# Altium schematic sheet TPS5430_buck_converter.SchDoc(TPS5430_buck_converter) (continued)

## Library data of the parts
C1 = C1608X7R1H103K080AA: C Series 0603 10 nF 50 V ±10 % Tolerance X7R SMT Multilayer Ceramic Capacitor; Manufacturer: TDK; Manufacturer Lifecycle Status: PRODUCTION; Tolerance: 10%; Case Code (Imperial): 0603; Case Code (Metric): 1608; Case/Package: 0603; Category: Ceramic Capacitors; China RoHS: Compliant; Component Kind: Standard; ComponentLink1Description: https://datasheet.octopart.com:443/C1608X7R1H103K080AA-TDK-datasheet-175423548.pdf?src-supplier=TME; ComponentLink1URL: https://datasheet.octopart.com:443/C1608X7R1H103K080AA-TDK-datasheet-175423548.pdf?src-supplier=TME; ComponentLink2Description: https://datasheet.octopart.com:443/C1608X7R1H103K080AA-TDK-datasheet-182135025.pdf?src-supplier=TME; ComponentLink2URL: https://datasheet.octopart.com:443/C1608X7R1H103K080AA-TDK-datasheet-182135025.pdf?src-supplier=TME; ComponentLink3Description: https://datasheet.octopart.com:443/C1608X7R1H103K080AA-TDK-datasheet-159617022.pdf?src-supplier=TME; ComponentLink3URL: https://datasheet.octopart.com:443/C1608X7R1H103K080AA-TDK-datasheet-159617022.pdf?src-supplier=TME; ComponentLink4Description: https://datasheet.octopart.com:443/C1608X7R1H103K080AA-TDK-datasheet-151546523.pdf?src-supplier=ODG+(Origin+Data+Global); ComponentLink4URL: https://datasheet.octopart.com:443/C1608X7R1H103K080AA-TDK-datasheet-151546523.pdf?src-supplier=ODG+(Origin+Data+Global); ComponentLink5Description: https://datasheet.octopart.com:443/C1608X7R1H103K080AA-TDK-datasheet-82475248.pdf?src-supplier=Newark; ComponentLink5URL: https://datasheet.octopart.com:443/C1608X7R1H103K080AA-TDK-datasheet-82475248.pdf?src-supplier=Newark; ComponentLink6Description: https://datasheet.octopart.com:443/C1608X7R1H103K080AA-TDK-datasheet-13376850.pdf?src-supplier=Newark; ComponentLink6URL: https://datasheet.octopart.com:443/C1608X7R1H103K080AA-TDK-datasheet-13376850.pdf?src-supplier=Newark; ComponentLink7Description: https://datasheet.octopart.com:443/C1608X7R1H103K080AA-TDK-datasheet-10978788.pdf?src-supplier=Newark; ComponentLink7URL: https://datasheet.octopart.com:443/C1608X7R1H103K080AA-TDK-datasheet-10978788.pdf?src-supplier=Newark; ComponentLink8Description: https://datasheet.octopart.com/C1608X7R1H103K080AA-TDK-datasheet-41194876.pdf; ComponentLink8URL: https://datasheet.octopart.com/C1608X7R1H103K080AA-TDK-datasheet-41194876.pdf; ComponentLink9Description: https://datasheet.octopart.com:443/C1608X7R1H103K080AA-TDK-datasheet-21217870.pdf?src-supplier=element14+APAC; ComponentLink9URL: https://datasheet.octopart.com:443/C1608X7R1H103K080AA-TDK-datasheet-21217870.pdf?src-supplier=element14+APAC; ComponentLink10Description: https://datasheet.octopart.com:443/C1608X7R1H103K080AA-TDK-datasheet-8852320.pdf?src-supplier=Farnell; ComponentLink10URL: https://datasheet.octopart.com:443/C1608X7R1H103K080AA-TDK-datasheet-8852320.pdf?src-supplier=Farnell; ComponentLink11Description: https://datasheet.octopart.com:443/C1608X7R1H103K080AA-TDK-datasheet-15994800.pdf?src-supplier=Farnell; ComponentLink11URL: https://datasheet.octopart.com:443/C1608X7R1H103K080AA-TDK-datasheet-15994800.pdf?src-supplier=Farnell; ComponentLink12Description: https://datasheet.octopart.com:443/C1608X7R1H103K080AA-TDK-datasheet-12509848.pdf?src-supplier=Future+Electronics; ComponentLink12URL: https://datasheet.octopart.com:443/C1608X7R1H103K080AA-TDK-datasheet-12509848.pdf?src-supplier=Future+Electronics; ComponentLink13Description: https://datasheet.octopart.com:443/C1608X7R1H103K080AA-TDK-datasheet-8359770.pdf?src-supplier=Future+Electronics; ComponentLink13URL: https://datasheet.octopart.com:443/C1608X7R1H103K080AA-TDK-datasheet-8359770.pdf?src-supplier=Future+Electronics; ComponentLink14Description: https://datasheet.octopart.com:443/C1608X7R1H103K080AA-TDK-datasheet-8324322.pdf?src-supplier=Future+Electronics; ComponentLink14URL: https://datasheet.octopart.com:443/C1608X7R1H103K080AA-TDK-datasheet-8324322.pdf?src-supplier=Future+Electronics; ComponentLink15Description: https://datasheet.octopart.com:443/C1608X7R1H103K080AA-TDK-datasheet-128946.pdf?src-supplier=Future+Electronics; ComponentLink15URL: https://datasheet.octopart.com:443/C1608X7R1H103K080AA-TDK-datasheet-128946.pdf?src-supplier=Future+Electronics; Composition: Ceramic; Depth: 800µm; Dielectric: X7R; Dielectric Material: Ceramic; Height: 800µm; Lead Free: Lead Free; Length: 1.6mm; Library Name: TPS5430_buck _converter.SchLib; Lifecycle Status: Production; Manufacturer Part Number: C1608X7R1H103K080AA; Material: Ceramic; Max Length: 1.7mm; Max Operating Temperature: 125°C; Max Width: 900µm; Min Length: 1.5mm; Min Operating Temperature: -55°C; Min Width: 700µm; Mounting Technology: Surface Mount; Number of Terminals: 2; Pricing: 1=0.1, 10=0.034, 50=0.034, 100=0.03, 1000=0.019, 10000=0.010 (USD); Radiation Hardening: No; REACH SVHC: Yes; RoHS: Compliant; Schedule B: 8532240020, 8532240020|8532240020, 8532240020|8532240020|8532240020, 8532240020|8532240020|8532240020|8532240020; Stock: 251669; Supplier: Mouser; Supplier Part Number: 810-C1608X7R1H103K; Termination: SMD/SMT; Value: 10nF; Voltage: 50V; Voltage Rating: 50V; Voltage Rating (DC): 50V; Weight: 2.012816mg; Width: 800µm
C2 C3 = C4532X7R1H475M200KB: Multilayer Ceramic Capacitor, C Series, 4.7 - F, - 20%, X7R, 50 V, 1812 [4532 Metric]; Manufacturer: TDK; Manufacturer Lifecycle Status: PRODUCTION (NOT RECOMMENDED FOR NEW DESIGN); Value: 4.7µF; Voltage Rating: 50V; Case Code (Imperial): 1812; Case Code (Metric): 4532; Case/Package: 1812; Category: Ceramic Capacitors; China RoHS: Compliant; Component Kind: Standard; ComponentLink1Description: https://datasheet.octopart.com:443/C4532X7R1H475M200KB-TDK-datasheet-175423548.pdf?src-supplier=IHS; ComponentLink1URL: https://datasheet.octopart.com:443/C4532X7R1H475M200KB-TDK-datasheet-175423548.pdf?src-supplier=IHS; ComponentLink2Description: https://sigma.octopart.com:443/138898468/technical_drawing/TDK-C4532X7R1H475M200KB.pdf?src-supplier=Upverter; ComponentLink2URL: https://sigma.octopart.com:443/138898468/technical_drawing/TDK-C4532X7R1H475M200KB.pdf?src-supplier=Upverter; ComponentLink3Description: https://datasheet.octopart.com:443/C4532X7R1H475M200KB-TDK-datasheet-14433597.pdf?src-supplier=Upverter; ComponentLink3URL: https://datasheet.octopart.com:443/C4532X7R1H475M200KB-TDK-datasheet-14433597.pdf?src-supplier=Upverter; ComponentLink4Description: https://datasheet.octopart.com:443/C4532X7R1H475M200KB-TDK-datasheet-151546523.pdf?src-supplier=ODG+(Origin+Data+Global); ComponentLink4URL: https://datasheet.octopart.com:443/C4532X7R1H475M200KB-TDK-datasheet-151546523.pdf?src-supplier=ODG+(Origin+Data+Global); ComponentLink5Description: https://datasheet.octopart.com/C4532X7R1H475M200KB-TDK-datasheet-41194876.pdf; ComponentLink5URL: https://datasheet.octopart.com/C4532X7R1H475M200KB-TDK-datasheet-41194876.pdf; ComponentLink6Description: https://datasheet.octopart.com/C4532X7R1H475M200KB-TDK-datasheet-15532982.pdf; ComponentLink6URL: https://datasheet.octopart.com/C4532X7R1H475M200KB-TDK-datasheet-15532982.pdf; ComponentLink7Description: https://datasheet.octopart.com:443/C4532X7R1H475M200KB-TDK-datasheet-10978788.pdf?src-supplier=Newark; ComponentLink7URL: https://datasheet.octopart.com:443/C4532X7R1H475M200KB-TDK-datasheet-10978788.pdf?src-supplier=Newark; ComponentLink8Description: https://datasheet.octopart.com:443/C4532X7R1H475M200KB-TDK-datasheet-13376850.pdf?src-supplier=Newark; ComponentLink8URL: https://datasheet.octopart.com:443/C4532X7R1H475M200KB-TDK-datasheet-13376850.pdf?src-supplier=Newark; ComponentLink9Description: https://datasheet.octopart.com:443/C4532X7R1H475M200KB-TDK-datasheet-8852320.pdf?src-supplier=Newark; ComponentLink9URL: https://datasheet.octopart.com:443/C4532X7R1H475M200KB-TDK-datasheet-8852320.pdf?src-supplier=Newark; ComponentLink10Description: https://datasheet.octopart.com:443/C4532X7R1H475M200KB-TDK-datasheet-82475248.pdf?src-supplier=Newark; ComponentLink10URL: https://datasheet.octopart.com:443/C4532X7R1H475M200KB-TDK-datasheet-82475248.pdf?src-supplier=Newark; ComponentLink11Description: https://datasheet.octopart.com:443/C4532X7R1H475M200KB-TDK-datasheet-23766614.pdf?src-supplier=Farnell; ComponentLink11URL: https://datasheet.octopart.com:443/C4532X7R1H475M200KB-TDK-datasheet-23766614.pdf?src-supplier=Farnell; ComponentLink12Description: https://datasheet.octopart.com:443/C4532X7R1H475M200KB-TDK-datasheet-12509848.pdf?src-supplier=Future+Electronics; ComponentLink12URL: https://datasheet.octopart.com:443/C4532X7R1H475M200KB-TDK-datasheet-12509848.pdf?src-supplier=Future+Electronics; ComponentLink13Description: https://datasheet.octopart.com:443/C4532X7R1H475M200KB-TDK-datasheet-8359770.pdf?src-supplier=Future+Electronics; ComponentLink13URL: https://datasheet.octopart.com:443/C4532X7R1H475M200KB-TDK-datasheet-8359770.pdf?src-supplier=Future+Electronics; ComponentLink14Description: https://datasheet.octopart.com:443/C4532X7R1H475M200KB-TDK-datasheet-8324322.pdf?src-supplier=Future+Electronics; ComponentLink14URL: https://datasheet.octopart.com:443/C4532X7R1H475M200KB-TDK-datasheet-8324322.pdf?src-supplier=Future+Electronics; ComponentLink15Description: https://datasheet.octopart.com:443/C4532X7R1H475M200KB-TDK-datasheet-128946.pdf?src-supplier=Future+Electronics; ComponentLink15URL: https://datasheet.octopart.com:443/C4532X7R1H475M200KB-TDK-datasheet-128946.pdf?src-supplier=Future+Electronics; ComponentLink16Description: http://sigma.octopart.com:80/rohs_certificate/C-Series-Ceramic-Chip-Capacitors-TDK-family-108.pdf?src-supplier=Compliance+Statements; ComponentLink16URL: http://sigma.octopart.com:80/rohs_certificate/C-Series-Ceramic-Chip-Capacitors-TDK-family-108.pdf?src-supplier=Compliance+Statements; Composition: Ceramic; Depth: 3.2mm; Dielectric: X7R; Dielectric Material: Ceramic; Height: 2mm; Lead Free: Lead Free; Length: 4.5mm; Library Name: TPS5430_buck _converter.SchLib; Lifecycle Status: NRND; Manufacturer Part Number: C4532X7R1H475M200KB; Material: Ceramic; Max Length: 4.9mm; Max Operating Temperature: 125°C; Max Width: 3.6mm; Min Length: 4.1mm; Min Operating Temperature: -55°C; Min Width: 2.8mm; Mounting Technology: Surface Mount; Number of Terminals: 2; Pins: 2; Pricing: 1=0.83, 10=0.525, 50=0.525, 100=0.479, 1000=0.323, 10000=0.322 (USD); REACH SVHC: Yes; RoHS: Compliant; Schedule B: 8532240020, 8532240020|8532240020|8532240020|8532240020|8532240020|8532240020|8532240020, 8532240020|8532240020|8532240020|8532240020|8532240020|8532240020|8532240020|8532240020, 8532240020|8532240020|8532240020|8532240020|8532240020|8532240020|8532240020|8532240020|8532240020; Stock: 11670; Supplier: Mouser; Supplier Part Number: 810-C4532X7R1H475M-9; Termination: SMD/SMT; Tolerance: 20%; Voltage Rating (DC): 50V; Weight: 26.988746mg; Width: 3.2mm
C4 = 10TPB220M: Cap Tant Solid 220uF 10V D4 CASE 20% (7.3 X 4.3 X 3.8mm) SMD 7343 0.04 Ohm 105 C Embossed T/R; Manufacturer: Panasonic; Manufacturer Lifecycle Status: ACTIVE; Part Series: TPB; Dissipation Factor: 10%; ESR (Equivalent Series Resistance): 40mΩ; Leakage Current: 220µA; Life (Hours): 2000hours; Material: Polymer; Polarity: Polar; Ripple Current: 3A; Value: 220µF; Case Code (Imperial): 2917; Case Code (Metric): 7343; Case/Package: 2917; Category: Tantalum Capacitors; Component Kind: Standard; ComponentLink1Description: https://datasheet.octopart.com:443/10TPB220M-Panasonic-datasheet-182100652.pdf?src-supplier=IHS; ComponentLink1URL: https://datasheet.octopart.com:443/10TPB220M-Panasonic-datasheet-182100652.pdf?src-supplier=IHS; ComponentLink2Description: https://datasheet.octopart.com:443/10TPB220M-Panasonic-datasheet-61627562.pdf?src-supplier=Panasonic; ComponentLink2URL: https://datasheet.octopart.com:443/10TPB220M-Panasonic-datasheet-61627562.pdf?src-supplier=Panasonic; ComponentLink3Description: https://sigma.octopart.com:443/151312658/technical_drawing/Panasonic-10TPB220M.pdf?src-supplier=Upverter; ComponentLink3URL: https://sigma.octopart.com:443/151312658/technical_drawing/Panasonic-10TPB220M.pdf?src-supplier=Upverter; ComponentLink4Description: https://datasheet.octopart.com:443/10TPB220M-Panasonic-datasheet-136612378.pdf?src-supplier=Farnell; ComponentLink4URL: https://datasheet.octopart.com:443/10TPB220M-Panasonic-datasheet-136612378.pdf?src-supplier=Farnell; ComponentLink5Description: https://datasheet.octopart.com:443/10TPB220M-Panasonic-datasheet-18423120.pdf?src-supplier=Farnell; ComponentLink5URL: https://datasheet.octopart.com:443/10TPB220M-Panasonic-datasheet-18423120.pdf?src-supplier=Farnell; ComponentLink6Description: https://datasheet.octopart.com:443/10TPB220M-Panasonic-datasheet-17275940.pdf?src-supplier=Future+Electronics; ComponentLink6URL: https://datasheet.octopart.com:443/10TPB220M-Panasonic-datasheet-17275940.pdf?src-supplier=Future+Electronics; ComponentLink7Description: https://datasheet.octopart.com:443/10TPB220M-Panasonic-datasheet-154766054.pdf?src-supplier=Master+Electronics; ComponentLink7URL: https://datasheet.octopart.com:443/10TPB220M-Panasonic-datasheet-154766054.pdf?src-supplier=Master+Electronics; ComponentLink8Description: https://datasheet.octopart.com:443/10TPB220M..-Panasonic-datasheet-527723.pdf?src-supplier=element14+APAC; ComponentLink8URL: https://datasheet.octopart.com:443/10TPB220M..-Panasonic-datasheet-527723.pdf?src-supplier=element14+APAC; ComponentLink9Description: https://datasheet.octopart.com:443/10TPB220M-Panasonic-datasheet-17909813.pdf?src-supplier=Electro+Sonic; ComponentLink9URL: https://datasheet.octopart.com:443/10TPB220M-Panasonic-datasheet-17909813.pdf?src-supplier=Electro+Sonic; ComponentLink10Description: https://datasheet.octopart.com/10TPB220M-Panasonic-datasheet-16721291.pdf; ComponentLink10URL: https://datasheet.octopart.com/10TPB220M-Panasonic-datasheet-16721291.pdf; ComponentLink11Description: https://datasheet.octopart.com:443/10TPB220M-Panasonic-datasheet-41466108.pdf?src-supplier=RS+(Formerly+Allied+Electronics); ComponentLink11URL: https://datasheet.octopart.com:443/10TPB220M-Panasonic-datasheet-41466108.pdf?src-supplier=RS+(Formerly+Allied+Electronics); Depth: 4.3mm; Height: 3.8mm; Height - Seated (Max): 2.9972mm; Lead Free: Lead Free; Length: 7.3mm; Library Name: TPS5430_buck _converter.SchLib; Lifecycle Status: Production; Manufacturer Part Number: 10TPB220M; Max Operating Temperature: 105°C; Min Operating Temperature: -55°C; Mounting Technology: Surface Mount; Number of Terminals: 2; Pins: 4; Pricing: 1=2.14, 1=1.84, 10=1.18, 10=1.01, 50=1.18, 100=1.1, 100=0.946, 500=0.85, 1000=0.909, 2000=0.85, 4000=0.83, 10000=0.843, 10000=0.79 (USD); Radiation Hardening: No; REACH SVHC: Yes; RoHS: Compliant; Schedule B: 8532210080, 8532210080|8532210080|8532210080|8532210080|8532210080|8532210080|8532210080|8532210080|8532210080|8532210080|8532210080|8532210080|8532210080|8532210080|8532210080|8532210080|8532210080|8532210080|8532210080|8532210080|8532210080|8532210080|8532210080|85; Stock: 5479; Supplier: Mouser; Supplier Part Number: 667-10TPB220M; Termination: SMD/SMT; Tolerance: 20%; Voltage: 10V; Voltage Rating: 10V; Voltage Rating (DC): 10V; Width: 4.3mm
C5 = 08055C104JAT2A: 0805 100nF 50 V ±5 % Tolerance X7R Surface Mount Multilayer Ceramic Capacitor; Manufacturer: KYOCERA AVX; Dissipation Factor: 2.5%; Insulation Resistance: 100GΩ; Tolerance: 5%; Voltage: 50V; Case Code (Imperial): 0805; Case/Package: 0805; Category: Ceramic Capacitors; China RoHS: Compliant; Component Kind: Standard; ComponentLink1Description: https://datasheet.octopart.com:443/08055C104JAT2A-KYOCERA-AVX-datasheet-170560559.pdf?src-supplier=IHS; ComponentLink1URL: https://datasheet.octopart.com:443/08055C104JAT2A-KYOCERA-AVX-datasheet-170560559.pdf?src-supplier=IHS; ComponentLink2Description: https://sigma.octopart.com:443/147443767/technical_drawing/AVX-08055C104JAT2A.pdf?src-supplier=Upverter; ComponentLink2URL: https://sigma.octopart.com:443/147443767/technical_drawing/AVX-08055C104JAT2A.pdf?src-supplier=Upverter; ComponentLink3Description: https://datasheet.octopart.com:443/08055C104JAT2A-AVX-datasheet-12509786.pdf?src-supplier=Farnell; ComponentLink3URL: https://datasheet.octopart.com:443/08055C104JAT2A-AVX-datasheet-12509786.pdf?src-supplier=Farnell; ComponentLink4Description: https://datasheet.octopart.com:443/08055C104JAT2A-AVX-datasheet-12587061.pdf?src-supplier=Farnell; ComponentLink4URL: https://datasheet.octopart.com:443/08055C104JAT2A-AVX-datasheet-12587061.pdf?src-supplier=Farnell; ComponentLink5Description: https://datasheet.octopart.com:443/08055C104JAT2A-AVX-datasheet-8113618.pdf?src-supplier=Newark; ComponentLink5URL: https://datasheet.octopart.com:443/08055C104JAT2A-AVX-datasheet-8113618.pdf?src-supplier=Newark; ComponentLink6Description: https://datasheet.octopart.com:443/08055C104JAT2A-KYOCERA-AVX-datasheet-158983632.pdf?src-supplier=Newark; ComponentLink6URL: https://datasheet.octopart.com:443/08055C104JAT2A-KYOCERA-AVX-datasheet-158983632.pdf?src-supplier=Newark; ComponentLink7Description: https://datasheet.octopart.com:443/08055C104JAT2A-AVX-datasheet-78690206.pdf?src-supplier=Newark; ComponentLink7URL: https://datasheet.octopart.com:443/08055C104JAT2A-AVX-datasheet-78690206.pdf?src-supplier=Newark; ComponentLink8Description: https://datasheet.octopart.com:443/08055C104JAT2A-KYOCERA-AVX-datasheet-65931687.pdf?src-supplier=Newark; ComponentLink8URL: https://datasheet.octopart.com:443/08055C104JAT2A-KYOCERA-AVX-datasheet-65931687.pdf?src-supplier=Newark; ComponentLink9Description: https://datasheet.octopart.com:443/08055C-104JAT2A-AVX-datasheet-26446220.pdf?src-supplier=Newark; ComponentLink9URL: https://datasheet.octopart.com:443/08055C-104JAT2A-AVX-datasheet-26446220.pdf?src-supplier=Newark; ComponentLink10Description: https://datasheet.octopart.com:443/08055C104JAT2A-AVX-datasheet-5313617.pdf?src-supplier=Newark; ComponentLink10URL: https://datasheet.octopart.com:443/08055C104JAT2A-AVX-datasheet-5313617.pdf?src-supplier=Newark; ComponentLink11Description: https://datasheet.octopart.com:443/08055C104JAT2A-AVX-datasheet-167033.pdf?src-supplier=Newark; ComponentLink11URL: https://datasheet.octopart.com:443/08055C104JAT2A-AVX-datasheet-167033.pdf?src-supplier=Newark; ComponentLink12Description: https://datasheet.octopart.com:443/08055C104JAT2A-AVX---Kyocera-datasheet-8446237.pdf?src-supplier=TME; ComponentLink12URL: https://datasheet.octopart.com:443/08055C104JAT2A-AVX---Kyocera-datasheet-8446237.pdf?src-supplier=TME; ComponentLink13Description: https://datasheet.octopart.com:443/08055C104JAT2A-KYOCERA-AVX-datasheet-156093841.pdf?src-supplier=TME; ComponentLink13URL: https://datasheet.octopart.com:443/08055C104JAT2A-KYOCERA-AVX-datasheet-156093841.pdf?src-supplier=TME; ComponentLink14Description: https://datasheet.octopart.com:443/08055C104JAT2A-AVX-datasheet-166051239.pdf?src-supplier=Amar+Radio+Corporation; ComponentLink14URL: https://datasheet.octopart.com:443/08055C104JAT2A-AVX-datasheet-166051239.pdf?src-supplier=Amar+Radio+Corporation; ComponentLink15Description: https://datasheet.octopart.com:443/08055C104JAT2A-AVX-datasheet-50865064.pdf?src-supplier=Future+Electronics; ComponentLink15URL: https://datasheet.octopart.com:443/08055C104JAT2A-AVX-datasheet-50865064.pdf?src-supplier=Future+Electronics; ComponentLink16Description: https://datasheet.octopart.com:443/08055C104JAT2A-KYOCERA-AVX-datasheet-50865067.pdf?src-supplier=Future+Electronics; ComponentLink16URL: https://datasheet.octopart.com:443/08055C104JAT2A-KYOCERA-AVX-datasheet-50865067.pdf?src-supplier=Future+Electronics; ComponentLink17Description: https://datasheet.octopart.com:443/08055C104JAT2A-AVX-datasheet-12340990.pdf?src-supplier=Future+Electronics; ComponentLink17URL: https://datasheet.octopart.com:443/08055C104JAT2A-AVX-datasheet-12340990.pdf?src-supplier=Future+Electronics; ComponentLink18Description: https://datasheet.octopart.com:443/08055C104JAT2A-AVX-datasheet-8448153.pdf?src-supplier=Future+Electronics; ComponentLink18URL: https://datasheet.octopart.com:443/08055C104JAT2A-AVX-datasheet-8448153.pdf?src-supplier=Future+Electronics; ComponentLink19Description: https://datasheet.octopart.com:443/08055C104JAT2A-AVX-datasheet-5314571.pdf?src-supplier=Future+Electronics; ComponentLink19URL: https://datasheet.octopart.com:443/08055C104JAT2A-AVX-datasheet-5314571.pdf?src-supplier=Future+Electronics; ComponentLink20Description: https://datasheet.octopart.com/08055C104JAT2A-AVX---Kyocera-datasheet-13516023.pdf; ComponentLink20URL: https://datasheet.octopart.com/08055C104JAT2A-AVX---Kyocera-datasheet-13516023.pdf; ComponentLink21Description: https://datasheet.octopart.com/Commercial-Surface-Mount-Chips---X7R-Dielectric-AVX-datasheet-family-100.pdf; ComponentLink21URL: https://datasheet.octopart.com/Commercial-Surface-Mount-Chips---X7R-Dielectric-AVX-datasheet-family-100.pdf; ComponentLink22Description: https://datasheet.octopart.com:443/08055C104JAT2A-AVX-datasheet-5377487.pdf?src-supplier=Garrett; ComponentLink22URL: https://datasheet.octopart.com:443/08055C104JAT2A-AVX-datasheet-5377487.pdf?src-supplier=Garrett; ComponentLink23Description: https://datasheet.octopart.com:443/08055C104JAT2A-AVX-datasheet-5383.pdf?src-supplier=Garrett; ComponentLink23URL: https://datasheet.octopart.com:443/08055C104JAT2A-AVX-datasheet-5383.pdf?src-supplier=Garrett; ComponentLink24Description: https://datasheet.octopart.com:443/08055C104JAT2A-AVX-datasheet-148183.pdf?src-supplier=Garrett; ComponentLink24URL: https://datasheet.octopart.com:443/08055C104JAT2A-AVX-datasheet-148183.pdf?src-supplier=Garrett; ComponentLink25Description: https://datasheet.octopart.com:443/08055C104JAT2A-AVX-datasheet-17703057.pdf?src-supplier=Jameco; ComponentLink25URL: https://datasheet.octopart.com:443/08055C104JAT2A-AVX-datasheet-17703057.pdf?src-supplier=Jameco; ComponentLink26Description: https://datasheet.octopart.com:443/08055C104JAT2A-AVX-datasheet-12134.pdf?src-supplier=DigiKey; ComponentLink26URL: https://datasheet.octopart.com:443/08055C104JAT2A-AVX-datasheet-12134.pdf?src-supplier=DigiKey; ComponentLink27Description: https://datasheet.octopart.com:443/08055C104JAT2A-AVX-datasheet-4352.pdf?src-supplier=RS+(Formerly+Allied+Electronics); ComponentLink27URL: https://datasheet.octopart.com:443/08055C104JAT2A-AVX-datasheet-4352.pdf?src-supplier=RS+(Formerly+Allied+Electronics); ComponentLink28Description: https://datasheet.octopart.com:443/08055C104JAT2A-AVX-datasheet-111406.pdf?src-supplier=Sierra+IC; ComponentLink28URL: https://datasheet.octopart.com:443/08055C104JAT2A-AVX-datasheet-111406.pdf?src-supplier=Sierra+IC; ComponentLink29Description: http://sigma.octopart.com:80/reach_statement/AVX-company-19.pdf?src-supplier=Compliance+Statements; ComponentLink29URL: http://sigma.octopart.com:80/reach_statement/AVX-company-19.pdf?src-supplier=Compliance+Statements; ComponentLink30Description: http://sigma.octopart.com:80/conflict_mineral_statement/AVX-company-34.pdf?src-supplier=Compliance+Statements; ComponentLink30URL: http://sigma.octopart.com:80/conflict_mineral_statement/AVX-company-34.pdf?src-supplier=Compliance+Statements; Contact Plating: Tin; Depth: 1.25mm; Dielectric: X7R; Dielectric Material: Ceramic; Height: 940µm; Lead Free: Lead Free; Length: 2.01mm; Library Name: TPS5430_buck _converter.SchLib; Lifecycle Status: Production; Manufacturer Part Number: 08055C104JAT2A; Material: Ceramic; Max Operating Temperature: 125°C; Min Operating Temperature: -55°C; Mounting Technology: Surface Mount; Number of Terminals: 2; Packaging: Cut Tape; Pins: 2; Pricing: 1=0.11, 10=0.067, 50=0.067, 100=0.054, 1000=0.048, 10000=0.022 (USD); Radiation Hardening: No; REACH SVHC: Yes; RoHS: Compliant; Schedule B: 8532240020; Stock: 196751; Supplier: Mouser; Supplier Part Number: 581-08055C104J; Termination: SMD/SMT; Thickness: 939.8µm; Value: 100nF; Voltage Rating: 50V; Voltage Rating (DC): 50V; Width: 1.25mm
D1 = SBRV660VCTT4G: Rectifier Diode, Schottky, 1 Phase, 2 Element, 3A, 60V V(RRM), Silicon, TO-252AA; Manufacturer: onsemi; Manufacturer Lifecycle Status: ACTIVE; Forward Voltage: 900mV; Max Forward Surge Current (Ifsm): 75A; Number of Elements: 2; Peak Reverse Current: 100µA; Category: Schottky Diodes; China RoHS: Non-Compliant; Component Kind: Standard; ComponentLink1Description: https://datasheet.octopart.com:443/SBRV660VCTT4G-onsemi-datasheet-180961733.pdf?src-supplier=IHS; ComponentLink1URL: https://datasheet.octopart.com:443/SBRV660VCTT4G-onsemi-datasheet-180961733.pdf?src-supplier=IHS; ComponentLink2Description: https://datasheet.octopart.com:443/SBRV660VCTT4G-ON-Semiconductor-datasheet-102492028.pdf?src-supplier=Electro+Sonic; ComponentLink2URL: https://datasheet.octopart.com:443/SBRV660VCTT4G-ON-Semiconductor-datasheet-102492028.pdf?src-supplier=Electro+Sonic; ComponentLink3Description: https://datasheet.octopart.com:443/SBRV660VCTT4G-ON-Semiconductor-datasheet-12512686.pdf?src-supplier=onsemi; ComponentLink3URL: https://datasheet.octopart.com:443/SBRV660VCTT4G-ON-Semiconductor-datasheet-12512686.pdf?src-supplier=onsemi; ComponentLink4Description: https://datasheet.octopart.com:443/SBRV660VCTT4G-ON-Semiconductor-datasheet-10214096.pdf?src-supplier=onsemi; ComponentLink4URL: https://datasheet.octopart.com:443/SBRV660VCTT4G-ON-Semiconductor-datasheet-10214096.pdf?src-supplier=onsemi; ComponentLink5Description: https://datasheet.octopart.com:443/SBRV660VCTT4G-ON-Semiconductor-datasheet-499134.pdf?src-supplier=onsemi; ComponentLink5URL: https://datasheet.octopart.com:443/SBRV660VCTT4G-ON-Semiconductor-datasheet-499134.pdf?src-supplier=onsemi; ComponentLink6Description: http://sigma.octopart.com:80/150631386/rohs_statement/ON-Semiconductor-SBRV660VCTT4G.pdf?src-supplier=Compliance+Statements; ComponentLink6URL: http://sigma.octopart.com:80/150631386/rohs_statement/ON-Semiconductor-SBRV660VCTT4G.pdf?src-supplier=Compliance+Statements; ComponentLink7Description: http://sigma.octopart.com:80/reach_statement/ON-Semiconductor-company-79.pdf?src-supplier=Compliance+Statements; ComponentLink7URL: http://sigma.octopart.com:80/reach_statement/ON-Semiconductor-company-79.pdf?src-supplier=Compliance+Statements; ComponentLink8Description: https://octopart.com:443/opatz8j6/c1?t=skmVA2Tk48hCSv4JBsDvCzKTDhpROcRBF4pb5-2O3PTge5rixG54m8Wqa_haU8USgWLTTStIQU4gXw6CTz-k3Ch6zi0ZWzhmUfWqY84J_FZaX4AUrlEvOQKo1ospuUEYQuzqAsM28rPtf2Urj-wU39qiW6-0QEcd80wUgvXjIrG8Ywicbj2EGSrXFPgQSNqXULW6My-N-gEF7XgBkFwuQ_EYkt9HjRP-xIlPJAGzye0ODI7_-4paskqihhaQAG3smCzR8U11bmEDjxAlh_DlSLQYlJtc3Jfl3ZqNZNoFZItCVhrM9U1tTAifNu6DP1ambC86TZCGRICSCM3LrcpxKXL2qT5kWBuF2vBpPnoI5XwV&src-supplier=Compliance+Statements; ComponentLink8URL: https://octopart.com:443/opatz8j6/c1?t=skmVA2Tk48hCSv4JBsDvCzKTDhpROcRBF4pb5-2O3PTge5rixG54m8Wqa_haU8USgWLTTStIQU4gXw6CTz-k3Ch6zi0ZWzhmUfWqY84J_FZaX4AUrlEvOQKo1ospuUEYQuzqAsM28rPtf2Urj-wU39qiW6-0QEcd80wUgvXjIrG8Ywicbj2EGSrXFPgQSNqXULW6My-N-gEF7XgBkFwuQ_EYkt9HjRP-xIlPJAGzye0ODI7_-4paskqihhaQAG3smCzR8U11bmEDjxAlh_DlSLQYlJtc3Jfl3ZqNZNoFZItCVhrM9U1tTAifNu6DP1ambC86TZCGRICSCM3LrcpxKXL2qT5kWBuF2vBpPnoI5XwV&src-supplier=Compliance+Statements; ComponentLink9Description: https://octopart.com:443/opatz8j6/c1?t=9bG5G5rObXcmDaHcbUYnlNIIhbjysfrf32kUM4svh_p-Y3iZP7PXVdj4yRtU7Yw_wKSw9hs3Up7IEWdV405MXTiAdUfeRPZ40QZhyu_oA5WdXbGYmCIkJDG3tMZs8mQUvYOuN5ICujiIwC1eTbwuGUvE3bQrQB4ETCu76Q9PLmUA6mRh3vF4bbMeRAqrpMtWVHgTPdPsxorx_Y4U2mI3YtGlzifzH1bLPDuOwCqStTsvVykHP9xE_YWc0FWZ-lr03Zk3lLpSzR0BaFAh7HqnvsARjVbCbVdHoi4pOm6dhV9i0TwPF0KA3co3XX3Z1OBZsP6D40Js2HBnX1MJmtwiHE7Wo4HNTy7Cd94GBOuxkuI&src-supplier=Compliance+Statements; ComponentLink9URL: https://octopart.com:443/opatz8j6/c1?t=9bG5G5rObXcmDaHcbUYnlNIIhbjysfrf32kUM4svh_p-Y3iZP7PXVdj4yRtU7Yw_wKSw9hs3Up7IEWdV405MXTiAdUfeRPZ40QZhyu_oA5WdXbGYmCIkJDG3tMZs8mQUvYOuN5ICujiIwC1eTbwuGUvE3bQrQB4ETCu76Q9PLmUA6mRh3vF4bbMeRAqrpMtWVHgTPdPsxorx_Y4U2mI3YtGlzifzH1bLPDuOwCqStTsvVykHP9xE_YWc0FWZ-lr03Zk3lLpSzR0BaFAh7HqnvsARjVbCbVdHoi4pOm6dhV9i0TwPF0KA3co3XX3Z1OBZsP6D40Js2HBnX1MJmtwiHE7Wo4HNTy7Cd94GBOuxkuI&src-supplier=Compliance+Statements; Library Name: TPS5430_buck _converter.SchLib; Lifecycle Status: Production; Manufacturer Part Number: SBRV660VCTT4G; Max Operating Temperature: 175°C; Max Output current: 3A; Min Breakdown Voltage: 60V; Min Operating Temperature: -65°C; Number of Terminals: 2; Pricing: 1=1.34, 10=0.926, 50=0.926, 100=0.731, 1000=0.51, 10000=0.442 (USD); REACH SVHC: No; Reverse Standoff Voltage: 60V; RoHS: Compliant; Stock: 12470; Supplier: Mouser; Supplier Part Number: 863-SBRV660VCTT4G
J1 = 1985807: Conn PC Terminal Block 2 POS 3.5mm Solder ST Thru-Hole 13.5A; Manufacturer: Phoenix Contact; Approvals: UL; Connector Type: Screw; Current Rating: 10A; Max Current Rating: 12A; Max Supply Voltage: 63V; Number of Circuits: 2; Number of Levels: 1; Voltage: 300V; Wire Gauge (Max): 26AWG; Wire Gauge (Min): 16AWG; Category: Terminal Blocks; China RoHS: Compliant; Color: Black, Green; Component Kind: Standard; ComponentLink1Description: https://datasheet.octopart.com:443/1985807-Phoenix-Contact-datasheet-181096763.pdf?src-supplier=IHS; ComponentLink1URL: https://datasheet.octopart.com:443/1985807-Phoenix-Contact-datasheet-181096763.pdf?src-supplier=IHS; ComponentLink2Description: https://datasheet.octopart.com:443/1985807-Phoenix-Contact-datasheet-83202981.pdf?src-supplier=Newark; ComponentLink2URL: https://datasheet.octopart.com:443/1985807-Phoenix-Contact-datasheet-83202981.pdf?src-supplier=Newark; ComponentLink3Description: https://datasheet.octopart.com:443/1985807-Phoenix-Contact-datasheet-154784518.pdf?src-supplier=Electro+Sonic; ComponentLink3URL: https://datasheet.octopart.com:443/1985807-Phoenix-Contact-datasheet-154784518.pdf?src-supplier=Electro+Sonic; ComponentLink4Description: https://datasheet.octopart.com:443/1985807-Phoenix-Contact-datasheet-177372214.pdf?src-supplier=Burklin+Elektronik; ComponentLink4URL: https://datasheet.octopart.com:443/1985807-Phoenix-Contact-datasheet-177372214.pdf?src-supplier=Burklin+Elektronik; ComponentLink5Description: https://datasheet.octopart.com:443/1985807-Phoenix-Contact-datasheet-44019250.pdf?src-supplier=RS+(Formerly+Allied+Electronics); ComponentLink5URL: https://datasheet.octopart.com:443/1985807-Phoenix-Contact-datasheet-44019250.pdf?src-supplier=RS+(Formerly+Allied+Electronics); ComponentLink6Description: https://datasheet.octopart.com:443/1985807-Phoenix-Contact-datasheet-62123053.pdf?src-supplier=RS+(Formerly+Allied+Electronics); ComponentLink6URL: https://datasheet.octopart.com:443/1985807-Phoenix-Contact-datasheet-62123053.pdf?src-supplier=RS+(Formerly+Allied+Electronics); ComponentLink7Description: https://datasheet.octopart.com:443/1985807-Phoenix-Contact-datasheet-33536585.pdf?src-supplier=MRO+Stop; ComponentLink7URL: https://datasheet.octopart.com:443/1985807-Phoenix-Contact-datasheet-33536585.pdf?src-supplier=MRO+Stop; Current: 13.5A; Depth: 7.3mm; Flammability Rating: UL94 V-0; Height: 8.5mm; Housing Color: Black; Housing Material: Polyamide; Lead Free: Lead Free; Length: 7.5mm; Library Name: TPS5430_buck _converter.SchLib; Lifecycle Status: Production; Manufacturer Part Number: 1985807; Mounting Technology: Screw; Number of Contacts: 2; Number of Positions: 2; Number of Positions Per Level: 2; Number of Rows: 1; Orientation: Straight; Packaging: Bulk; Pins: 2; Pitch: 3.5mm; Pricing: 1=1.25, 10=1.25, 50=1.25, 100=1.25, 1000=1.25, 10000=1.25 (USD); Radiation Hardening: No; REACH SVHC: Yes; RoHS: Compliant; Stock: 818; Supplier: Mouser; Supplier Part Number: 651-1985807; Termination: Solder; Voltage Rating: 63V; Weight: 2.28001g; Wire/Cable Gauge: 16AWG
J2 = 1985807(output): Component Kind: Standard; Library Name: TPS5430_buck _converter.SchLib
L1 = SRR1208-220ML: Inductor Power Shielded Wirewound 22uH 20% 1KHz 23Q-Factor Ferrite 3.5A 0.043Ohm DCR T/R; Manufacturer: Bourns; DC Resistance (DCR): 43mΩ; Frequency: 7MHz; Material: Steel; Number of Circuits: 1; Q Factor: 23; Saturation Current: 4A; Shielding: Yes; Value: 22µH; Case/Package: 5050; Category: Fixed Inductors; China RoHS: Compliant; Component Kind: Standard; ComponentLink1Description: https://datasheet.octopart.com:443/SRR1208-220ML-Bourns-datasheet-154729978.pdf?src-supplier=IHS; ComponentLink1URL: https://datasheet.octopart.com:443/SRR1208-220ML-Bourns-datasheet-154729978.pdf?src-supplier=IHS; ComponentLink2Description: https://datasheet.octopart.com:443/SRR1208-220ML-Bourns-datasheet-68307255.pdf?src-supplier=element14+APAC; ComponentLink2URL: https://datasheet.octopart.com:443/SRR1208-220ML-Bourns-datasheet-68307255.pdf?src-supplier=element14+APAC; ComponentLink3Description: https://datasheet.octopart.com:443/SRR1208-220ML-Bourns-datasheet-11548354.pdf?src-supplier=Farnell; ComponentLink3URL: https://datasheet.octopart.com:443/SRR1208-220ML-Bourns-datasheet-11548354.pdf?src-supplier=Farnell; ComponentLink4Description: https://datasheet.octopart.com:443/SRR1208-220ML-Bourns-datasheet-41338362.pdf?src-supplier=RS+(Formerly+Allied+Electronics); ComponentLink4URL: https://datasheet.octopart.com:443/SRR1208-220ML-Bourns-datasheet-41338362.pdf?src-supplier=RS+(Formerly+Allied+Electronics); ComponentLink5Description: https://datasheet.octopart.com:443/SRR1208-220ML-Bourns-datasheet-37486976.pdf?src-supplier=RS+(Formerly+Allied+Electronics); ComponentLink5URL: https://datasheet.octopart.com:443/SRR1208-220ML-Bourns-datasheet-37486976.pdf?src-supplier=RS+(Formerly+Allied+Electronics); ComponentLink6Description: https://datasheet.octopart.com:443/SRR1208-220ML-Bourns-datasheet-25351.pdf?src-supplier=RS+(Formerly+Allied+Electronics); ComponentLink6URL: https://datasheet.octopart.com:443/SRR1208-220ML-Bourns-datasheet-25351.pdf?src-supplier=RS+(Formerly+Allied+Electronics); ComponentLink7Description: https://datasheet.octopart.com:443/SRR1208-220ML-Bourns-datasheet-56155.pdf?src-supplier=RS+(Formerly+Allied+Electronics); ComponentLink7URL: https://datasheet.octopart.com:443/SRR1208-220ML-Bourns-datasheet-56155.pdf?src-supplier=RS+(Formerly+Allied+Electronics); ComponentLink8Description: https://datasheet.octopart.com:443/SRR1208-220ML-Bourns-datasheet-5459063.pdf?src-supplier=Newark; ComponentLink8URL: https://datasheet.octopart.com:443/SRR1208-220ML-Bourns-datasheet-5459063.pdf?src-supplier=Newark; ComponentLink9Description: http://sigma.octopart.com:80/conflict_mineral_statement/Bourns-company-36.pdf?src-supplier=Compliance+Statements; ComponentLink9URL: http://sigma.octopart.com:80/conflict_mineral_statement/Bourns-company-36.pdf?src-supplier=Compliance+Statements; Composition: Wirewound; Core Material: Ferrite; Current Rating: 3.5A; DC Current: 3.5A; Depth: 13mm; Height: 8.5mm; Height - Seated (Max): 8.509mm; Inductance Tolerance: 20%; Length: 12.7mm; Library Name: TPS5430_buck _converter.SchLib; Lifecycle Status: Production; Manufacturer Part Number: SRR1208-220ML; Max Current Rating: 3.5A; Max DC Current: 3.5A; Max Operating Temperature: 125°C; Military Standard: Not; Min Operating Temperature: -40°C; Mounting Technology: Surface Mount; Number of Terminals: 2; Packaging: Cut Tape; Pins: 2; Pricing: 1=0.9, 10=0.741, 50=0.741, 100=0.609, 1000=0.478, 10000=0.478 (USD); REACH SVHC: Yes; RoHS: Compliant; Schedule B: 8504500000, 8504500000|8504500000|8504500000|8504500000|8504500000; Self Resonant Frequency: 7MHz; Stock: 1643; Supplier: Mouser; Supplier Part Number: 652-SRR1208-220ML; Termination: SMD/SMT; Test Frequency: 1kHz; Tolerance: 20%; Width: 12.7mm
R1 = CR0805-J/-000ELF: Zero Ohm Resistor, Jumper, 0805 [2012 Metric], Thick Film, 125 mW, 2 A, Surface Mount Device; Manufacturer: Bourns; Max Power Dissipation: 125mW; Tolerance: 5%; Value: 0Ω; Voltage Rating: 150V; Case Code (Imperial): 0805; Case Code (Metric): 2012; Case/Package: 0805; Category: Chip SMD Resistors; China RoHS: Non-Compliant; Component Kind: Standard; ComponentLink1Description: https://datasheet.octopart.com:443/CR0805-J--000ELF-Bourns-datasheet-159578630.pdf?src-supplier=ODG+(Origin+Data+Global); ComponentLink1URL: https://datasheet.octopart.com:443/CR0805-J--000ELF-Bourns-datasheet-159578630.pdf?src-supplier=ODG+(Origin+Data+Global); ComponentLink2Description: https://datasheet.octopart.com:443/CR0805-J--000ELF-Bourns-datasheet-159777434.pdf?src-supplier=IHS; ComponentLink2URL: https://datasheet.octopart.com:443/CR0805-J--000ELF-Bourns-datasheet-159777434.pdf?src-supplier=IHS; ComponentLink3Description: https://datasheet.octopart.com:443/CR0805-J--000ELF-Bourns-datasheet-68301634.pdf?src-supplier=element14+APAC; ComponentLink3URL: https://datasheet.octopart.com:443/CR0805-J--000ELF-Bourns-datasheet-68301634.pdf?src-supplier=element14+APAC; ComponentLink4Description: https://datasheet.octopart.com:443/CR0805-J--000ELF-Bourns-datasheet-28808732.pdf?src-supplier=element14+APAC; ComponentLink4URL: https://datasheet.octopart.com:443/CR0805-J--000ELF-Bourns-datasheet-28808732.pdf?src-supplier=element14+APAC; ComponentLink5Description: https://datasheet.octopart.com/CR0805-J/-000ELF-Bourns-datasheet-5318152.pdf; ComponentLink5URL: https://datasheet.octopart.com/CR0805-J/-000ELF-Bourns-datasheet-5318152.pdf; ComponentLink6Description: https://datasheet.octopart.com:443/CR0805-J--000ELF-Bourns-datasheet-154729663.pdf?src-supplier=Electro+Sonic; ComponentLink6URL: https://datasheet.octopart.com:443/CR0805-J--000ELF-Bourns-datasheet-154729663.pdf?src-supplier=Electro+Sonic; ComponentLink7Description: https://datasheet.octopart.com:443/CR0805-J--000ELF-Bourns-datasheet-34229249.pdf?src-supplier=Electro+Sonic; ComponentLink7URL: https://datasheet.octopart.com:443/CR0805-J--000ELF-Bourns-datasheet-34229249.pdf?src-supplier=Electro+Sonic; ComponentLink8Description: https://datasheet.octopart.com:443/CR0805-J--000ELF-Bourns-datasheet-11547086.pdf?src-supplier=Electro+Sonic; ComponentLink8URL: https://datasheet.octopart.com:443/CR0805-J--000ELF-Bourns-datasheet-11547086.pdf?src-supplier=Electro+Sonic; ComponentLink9Description: https://datasheet.octopart.com:443/CR0805-J--000ELF-Bourns-datasheet-24895818.pdf?src-supplier=Farnell; ComponentLink9URL: https://datasheet.octopart.com:443/CR0805-J--000ELF-Bourns-datasheet-24895818.pdf?src-supplier=Farnell; ComponentLink10Description: https://datasheet.octopart.com:443/CR0805-J--000ELF-Bourns-datasheet-22971629.pdf?src-supplier=Farnell; ComponentLink10URL: https://datasheet.octopart.com:443/CR0805-J--000ELF-Bourns-datasheet-22971629.pdf?src-supplier=Farnell; ComponentLink11Description: https://datasheet.octopart.com:443/CR0805-J--000ELF-Bourns-datasheet-49799764.pdf?src-supplier=RS+(Formerly+Allied+Electronics); ComponentLink11URL: https://datasheet.octopart.com:443/CR0805-J--000ELF-Bourns-datasheet-49799764.pdf?src-supplier=RS+(Formerly+Allied+Electronics); ComponentLink12Description: https://datasheet.octopart.com:443/CR0805-J--000ELF-Bourns-datasheet-140567134.pdf?src-supplier=Arrow.cn; ComponentLink12URL: https://datasheet.octopart.com:443/CR0805-J--000ELF-Bourns-datasheet-140567134.pdf?src-supplier=Arrow.cn; ComponentLink13Description: https://datasheet.octopart.com:443/CR0805-J/-000ELF-Bourns-datasheet-7563738.pdf?src-supplier=Jameco; ComponentLink13URL: https://datasheet.octopart.com:443/CR0805-J/-000ELF-Bourns-datasheet-7563738.pdf?src-supplier=Jameco; ComponentLink14Description: http://sigma.octopart.com:80/conflict_mineral_statement/Bourns-company-36.pdf?src-supplier=Compliance+Statements; ComponentLink14URL: http://sigma.octopart.com:80/conflict_mineral_statement/Bourns-company-36.pdf?src-supplier=Compliance+Statements; Composition: Thick Film; Contact Plating: Tin; Depth: 1.25mm; Height: 500µm; Library Name: TPS5430_buck _converter.SchLib; Lifecycle Status: Production; Manufacturer Part Number: CR0805-J/-000ELF; Max Operating Temperature: 155°C; Military Standard: Not; Min Operating Temperature: -55°C; Mounting Technology: Surface Mount; Number of Terminals: 2; Packaging: Cut Tape; Pins: 2; Power Dissipation: 125mW; Power Rating: 125mW; Pricing: 1=0.1, 10=0.009, 50=0.009, 100=0.006, 1000=0.005, 10000=0.003 (USD); Radiation Hardening: No; REACH SVHC: Yes; RoHS: Compliant; Stock: 182952; Supplier: Mouser; Supplier Part Number: 652-CR0805-J/-000ELF; Termination: SMD/SMT; Thickness: 508µm; Voltage Rating (DC): 150V; Width: 1.25mm
R2 = 1622829-1: Res Thick Film 0603 10K Ohm 1% 0.1W(1/10W) ±100ppm/C Pad SMD T/R; Manufacturer: TE Connectivity; Manufacturer Lifecycle Status: ACTIVE; Max Power Dissipation: 100mW; Number of Resistors: 1; Operating Supply Voltage: 50V; Temperature Coefficient: 100ppm/°C; Value: 10kΩ; Voltage Rating (DC): 75V; Case Code (Imperial): 0603; Case Code (Metric): 1608; Case/Package: 0603; Category: Chip SMD Resistors; China RoHS: Non-Compliant; Component Kind: Standard; ComponentLink1Description: https://datasheet.octopart.com:443/CRG0603F10K-TE-Connectivity-datasheet-167977698.pdf?src-supplier=IHS; ComponentLink1URL: https://datasheet.octopart.com:443/CRG0603F10K-TE-Connectivity-datasheet-167977698.pdf?src-supplier=IHS; ComponentLink2Description: https://datasheet.octopart.com:443/CRG0603F10K-TE-Connectivity-datasheet-182172479.pdf?src-supplier=IHS; ComponentLink2URL: https://datasheet.octopart.com:443/CRG0603F10K-TE-Connectivity-datasheet-182172479.pdf?src-supplier=IHS; ComponentLink3Description: https://datasheet.octopart.com:443/1622829-1-TE-Connectivity-datasheet-128558484.pdf?src-supplier=Master+Electronics; ComponentLink3URL: https://datasheet.octopart.com:443/1622829-1-TE-Connectivity-datasheet-128558484.pdf?src-supplier=Master+Electronics; ComponentLink4Description: https://datasheet.octopart.com:443/1622829-1-TE-Connectivity---AMP-datasheet-189108518.pdf?src-supplier=Master+Electronics; ComponentLink4URL: https://datasheet.octopart.com:443/1622829-1-TE-Connectivity---AMP-datasheet-189108518.pdf?src-supplier=Master+Electronics; ComponentLink5Description: https://datasheet.octopart.com:443/1622829-1-TE-Connectivity-datasheet-44624597.pdf?src-supplier=Electro+Sonic; ComponentLink5URL: https://datasheet.octopart.com:443/1622829-1-TE-Connectivity-datasheet-44624597.pdf?src-supplier=Electro+Sonic; ComponentLink6Description: https://datasheet.octopart.com:443/1622829-1-TE-Connectivity---AMP-datasheet-11120284.pdf?src-supplier=TE+Connectivity; ComponentLink6URL: https://datasheet.octopart.com:443/1622829-1-TE-Connectivity---AMP-datasheet-11120284.pdf?src-supplier=TE+Connectivity; ComponentLink7Description: https://datasheet.octopart.com:443/1622829-1-TE-Connectivity---AMP-datasheet-11120141.pdf?src-supplier=TE+Connectivity; ComponentLink7URL: https://datasheet.octopart.com:443/1622829-1-TE-Connectivity---AMP-datasheet-11120141.pdf?src-supplier=TE+Connectivity; ComponentLink8Description: https://datasheet.octopart.com:443/1622829-1-TE-Connectivity-datasheet-14513465.pdf?src-supplier=TE+Connectivity; ComponentLink8URL: https://datasheet.octopart.com:443/1622829-1-TE-Connectivity-datasheet-14513465.pdf?src-supplier=TE+Connectivity; ComponentLink9Description: https://datasheet.octopart.com:443/CRG0603F10K-TE-Connectivity-datasheet-111046813.pdf?src-supplier=RS+(Formerly+Allied+Electronics); ComponentLink9URL: https://datasheet.octopart.com:443/CRG0603F10K-TE-Connectivity-datasheet-111046813.pdf?src-supplier=RS+(Formerly+Allied+Electronics); ComponentLink10Description: https://datasheet.octopart.com:443/CRG0603F10K-TE-Connectivity-datasheet-20739855.pdf?src-supplier=RS+(Formerly+Allied+Electronics); ComponentLink10URL: https://datasheet.octopart.com:443/CRG0603F10K-TE-Connectivity-datasheet-20739855.pdf?src-supplier=RS+(Formerly+Allied+Electronics); ComponentLink11Description: https://datasheet.octopart.com:443/CRG0603F10K-Tyco-Electronics-datasheet-9696495.pdf?src-supplier=RS+(Formerly+Allied+Electronics); ComponentLink11URL: https://datasheet.octopart.com:443/CRG0603F10K-Tyco-Electronics-datasheet-9696495.pdf?src-supplier=RS+(Formerly+Allied+Electronics); ComponentLink12Description: http://sigma.octopart.com:80/14513464/rohs_certificate/Tyco-Electronics-CRG0603F10K.pdf?src-supplier=Compliance+Statements; ComponentLink12URL: http://sigma.octopart.com:80/14513464/rohs_certificate/Tyco-Electronics-CRG0603F10K.pdf?src-supplier=Compliance+Statements; ComponentLink13Description: http://sigma.octopart.com:80/38872672/materials_sheet/TE-Connectivity-CRG0603F10K.pdf?src-supplier=Compliance+Statements; ComponentLink13URL: http://sigma.octopart.com:80/38872672/materials_sheet/TE-Connectivity-CRG0603F10K.pdf?src-supplier=Compliance+Statements; ComponentLink14Description: http://sigma.octopart.com:80/78339091/materials_sheet/TE-Connectivity-CRG0603F10K.pdf?src-supplier=Compliance+Statements; ComponentLink14URL: http://sigma.octopart.com:80/78339091/materials_sheet/TE-Connectivity-CRG0603F10K.pdf?src-supplier=Compliance+Statements; Composition: Thick Film; Depth: 800µm; ELV: Compliant; Height: 450µm; Lead Free: Lead Free; Length: 1.6mm; Library Name: TPS5430_buck _converter.SchLib; Lifecycle Status: Production; Manufacturer Part Number: 1622829-1; Max Operating Temperature: 125°C; Military Standard: Not; Min Operating Temperature: -55°C; Mounting Technology: Surface Mount; Number of Terminals: 2; Number of Terminations: 2; Packaging: TapeandReel; Power Dissipation: 100mW; Power Rating: 100mW; Pricing: 1=0.1, 10=0.016, 50=0.016, 100=0.009, 1000=0.007, 10000=0.004 (USD); Radiation Hardening: No; REACH SVHC: Yes; RoHS: Compliant; Schedule B: 8533100070, 8533100070|8533100070, 8533100070|8533100070|8533100070, 8533100070|8533100070|8533100070|8533100070; Stock: 148991; Supplier: Mouser; Supplier Part Number: 279-1622829-1; Termination: Solder; Tolerance: 1%; Voltage Rating: 50V; Weight: 2.012816mg; Width: 800µm; Working Voltage: 50V
R3 = RC0603FR-073K24L: Resistor, thick film, SMD 0603 (1608), 3.24 kΩ, 0.1 W, ±1 %, RC0603FR-073K24L; Manufacturer: Yageo Group; Max Power Dissipation: 100mW; Temperature Coefficient: 100ppm/°C; Tolerance: 1%; Value: 3.24kΩ; Voltage Rating: 75V; Voltage Rating (DC): 50V; Case Code (Imperial): 0603; Case/Package: 0603; Category: Chip SMD Resistors; China RoHS: Non-Compliant; Component Kind: Standard; ComponentLink1Description: https://datasheet.octopart.com:443/RC0603FR-073K24L-Yageo-datasheet-175887523.pdf?src-supplier=Newark; ComponentLink1URL: https://datasheet.octopart.com:443/RC0603FR-073K24L-Yageo-datasheet-175887523.pdf?src-supplier=Newark; ComponentLink2Description: https://datasheet.octopart.com:443/RC0603FR-073K24L-Yageo-datasheet-103092701.pdf?src-supplier=Upverter; ComponentLink2URL: https://datasheet.octopart.com:443/RC0603FR-073K24L-Yageo-datasheet-103092701.pdf?src-supplier=Upverter; ComponentLink3Description: https://datasheet.octopart.com:443/RC0603FR-073K24L-Yageo-Group-datasheet-189192902.pdf?src-supplier=TME; ComponentLink3URL: https://datasheet.octopart.com:443/RC0603FR-073K24L-Yageo-Group-datasheet-189192902.pdf?src-supplier=TME; ComponentLink4Description: https://datasheet.octopart.com:443/RC0603FR-073K24L-Yageo-Group-datasheet-176638170.pdf?src-supplier=TME; ComponentLink4URL: https://datasheet.octopart.com:443/RC0603FR-073K24L-Yageo-Group-datasheet-176638170.pdf?src-supplier=TME; ComponentLink5Description: https://datasheet.octopart.com:443/RC0603FR-073K24L-Yageo-datasheet-8328172.pdf?src-supplier=Future+Electronics; ComponentLink5URL: https://datasheet.octopart.com:443/RC0603FR-073K24L-Yageo-datasheet-8328172.pdf?src-supplier=Future+Electronics; ComponentLink6Description: https://datasheet.octopart.com:443/RC0603FR-073K24L-Yageo-datasheet-164759695.pdf?src-supplier=Future+Electronics; ComponentLink6URL: https://datasheet.octopart.com:443/RC0603FR-073K24L-Yageo-datasheet-164759695.pdf?src-supplier=Future+Electronics; ComponentLink7Description: https://datasheet.octopart.com:443/RC0603FR-073K24L-Yageo-datasheet-137700087.pdf?src-supplier=Future+Electronics; ComponentLink7URL: https://datasheet.octopart.com:443/RC0603FR-073K24L-Yageo-datasheet-137700087.pdf?src-supplier=Future+Electronics; ComponentLink8Description: https://datasheet.octopart.com:443/RC0603FR-073K24L-Yageo-datasheet-127823281.pdf?src-supplier=Future+Electronics; ComponentLink8URL: https://datasheet.octopart.com:443/RC0603FR-073K24L-Yageo-datasheet-127823281.pdf?src-supplier=Future+Electronics; ComponentLink9Description: https://datasheet.octopart.com:443/RC0603FR-073K24L-Yageo-datasheet-27584510.pdf?src-supplier=Future+Electronics; ComponentLink9URL: https://datasheet.octopart.com:443/RC0603FR-073K24L-Yageo-datasheet-27584510.pdf?src-supplier=Future+Electronics; ComponentLink10Description: https://datasheet.octopart.com:443/RC0603FR-073K24L-Yageo-datasheet-15599030.pdf?src-supplier=Future+Electronics; ComponentLink10URL: https://datasheet.octopart.com:443/RC0603FR-073K24L-Yageo-datasheet-15599030.pdf?src-supplier=Future+Electronics; ComponentLink11Description: https://datasheet.octopart.com:443/RC0603FR-073K24L-Yageo-datasheet-12596169.pdf?src-supplier=Future+Electronics; ComponentLink11URL: https://datasheet.octopart.com:443/RC0603FR-073K24L-Yageo-datasheet-12596169.pdf?src-supplier=Future+Electronics; ComponentLink12Description: https://datasheet.octopart.com/RC0603FR-073K24L-Yageo-datasheet-73766026.pdf; ComponentLink12URL: https://datasheet.octopart.com/RC0603FR-073K24L-Yageo-datasheet-73766026.pdf; ComponentLink13Description: https://datasheet.octopart.com:443/RC0603FR-073K24L-Yageo-datasheet-118562.pdf?src-supplier=DigiKey; ComponentLink13URL: https://datasheet.octopart.com:443/RC0603FR-073K24L-Yageo-datasheet-118562.pdf?src-supplier=DigiKey; ComponentLink14Description: http://sigma.octopart.com:80/conflict_mineral_statement/Yageo-company-3.pdf?src-supplier=Compliance+Statements; ComponentLink14URL: http://sigma.octopart.com:80/conflict_mineral_statement/Yageo-company-3.pdf?src-supplier=Compliance+Statements; ComponentLink15Description: http://sigma.octopart.com:80/rohs_statement/Yageo-company-2.pdf?src-supplier=Compliance+Statements; ComponentLink15URL: http://sigma.octopart.com:80/rohs_statement/Yageo-company-2.pdf?src-supplier=Compliance+Statements; Composition: Thick Film; Depth: 800µm; Features: Moisture Resistant; Height: 450µm; Lead Free: Lead Free; Length: 1.6mm; Library Name: TPS5430_buck _converter.SchLib; Lifecycle Status: Production; Manufacturer Part Number: RC0603FR-073K24L; Max Operating Temperature: 155°C; Military Standard: Not; Min Operating Temperature: -55°C; Mounting Technology: Surface Mount; Number of Terminals: 2; Number of Terminations: 2; Packaging: Tape & Reel; Power Dissipation: 100mW; Power Rating: 100mW; Pricing: 1=0.1, 10=0.004, 50=0.004, 100=0.004, 1000=0.004, 10000=0.002 (USD); REACH SVHC: Yes; RoHS: Compliant; Schedule B: 8533210045, 8533210045|8533210045, 8533210045|8533210045|8533210045, 8533210045|8533210045|8533210045|8533210045; Stock: 699731; Supplier: Mouser; Supplier Part Number: 603-RC0603FR-073K24L; Termination: SMD/SMT; Weight: 2.012816mg; Width: 800µm; Working Voltage: 75V
TP1-TP6 = testpoint_smd: 1.5mm; Component Kind: Standard; Library Name: TPS5430_buck _converter.SchLib
